# Altium schematic sheet blip_sheet4.SchDoc
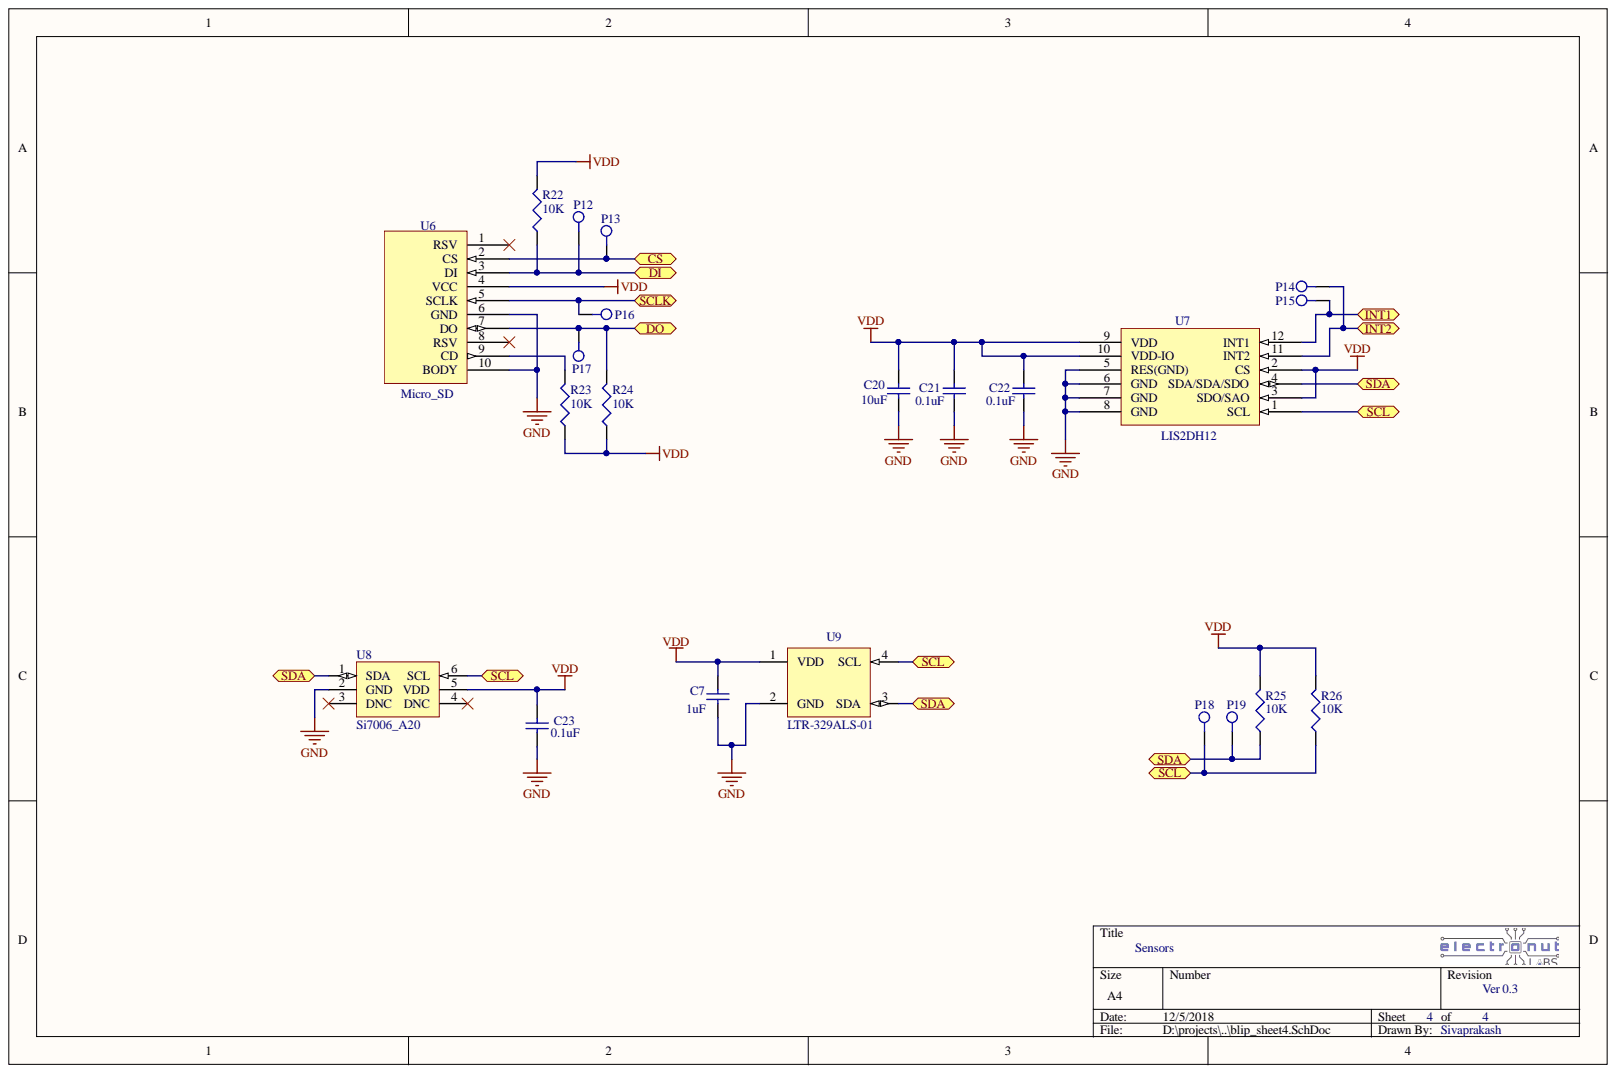
[diagram p0: blip_sheet4.SchDoc]

## Parts
C7  1uF  [1608[0603]]
C20  10uF  [1608[0603]]
C21  0.1uF  [1608[0603]]
C22  0.1uF  [1608[0603]]
C23  0.1uF  [1608[0603]]
P12  Test_Point  [TestPoint]  (value not drawn)
P13  Test_Point  [TestPoint]  (value not drawn)
P14  Test_Point  [TestPoint]  (value not drawn)
P15  Test_Point  [TestPoint]  (value not drawn)
P16  Test_Point  [TestPoint]  (value not drawn)
P17  Test_Point  [TestPoint]  (value not drawn)
P18  Test_Point  [TestPoint]  (value not drawn)
P19  Test_Point  [TestPoint]  (value not drawn)
R22  10K  [J1-0603]
R23  10K  [J1-0603]
R24  10K  [J1-0603]
R25  10K  [J1-0603]
R26  10K  [J1-0603]
U6  Micro_SD
U7  LIS2DH12  [Model Name]
U8  Si7006_A20  [Si7006-A20]
U9  LTR-329ALS-01  [LTR-329ALS-01]

## Ports
CS, DI, DO, INT1, INT2, SCL, SCLK, SDA

## Nets
GND: C7.1 C20.1 C21.1 C22.1 C23.1 U6.6(GND) U6.10(BODY) U7.5(RES(GND)) U7.6(GND) U7.7(GND) U7.8(GND) U8.2(GND) U9.2(GND)
VDD: C7.2 C20.2 C21.2 C22.2 C23.2 R22.2 R23.1 R24.1 R25.2 R26.2 U6.4(VCC) U7.2(CS) U7.3(SDO/SAO) U7.9(VDD) U7.10(VDD-IO) U8.5(VDD) U9.1(VDD)
CS: P13.1 U6.2(CS) ; port CS
DI: P12.1 R22.1 U6.3(DI) ; port DI
DO: P17.1 R24.2 U6.7(DO) ; port DO
INT1: P15.1 U7.12(INT1) ; port INT1
INT2: P14.1 U7.11(INT2) ; port INT2
SCL: P18.1 R26.1 U7.1(SCL) U8.6(SCL) U9.4(SCL) ; port SCL
SCLK: P16.1 U6.5(SCLK) ; port SCLK
SDA: P19.1 R25.1 U7.4(SDA/SDA/SDO) U8.1(SDA) U9.3(SDA) ; port SDA
NetR23_2: R23.2 U6.9(CD)

Not connected: U6 1(RSV) 8(RSV); U8 3(DNC) 4(DNC)

## Library data of the parts
C7 C20-C23 = Cap Semi: Capacitor (Semiconductor SIM Model); Component Kind: Standard; LatestRevisionDate: 29-May-2009; LatestRevisionNote: IPC-7351 Footprint Added.; Library Name: Miscellaneous Devices.IntLib; PackageDescription: Chip Capacitor; PackageReference: C1206; Published: 8-Jun-2000; Signal Integrity: Cap Semi; Simulation: CAP; Publisher: Altium Limited
R22-R26 = Res3: Resistor; Component Kind: Standard; LatestRevisionDate: 29-May-2009; LatestRevisionNote: IPC-7351 Footprint Added.; Library Name: Miscellaneous Devices.IntLib; PackageDescription: Chip Resistor; PackageReference: J1-0603; Published: 8-Jun-2000; Signal Integrity: Res; Simulation: RESISTOR; Publisher: Altium Limited
U6 = Micro_SD: Micro SD Card Holder; Component Kind: Standard; Library Name: blip.SchLib
U7 = LIS2DH12: 3 axis accelerometer; Component Kind: Standard; Library Name: blip.SchLib
U8 = Si7006_A20: Temm/ Humi Sensor from Silicon Lab; Component Kind: Standard; Library Name: blip.SchLib
P12-P19 = Test_Point: Component Kind: Standard; Library Name: blip.SchLib
U9 = LTR-329ALS-01: Component Kind: Standard; Library Name: blip.SchLib
